# Altium schematic sheet USBC_B.SchDoc
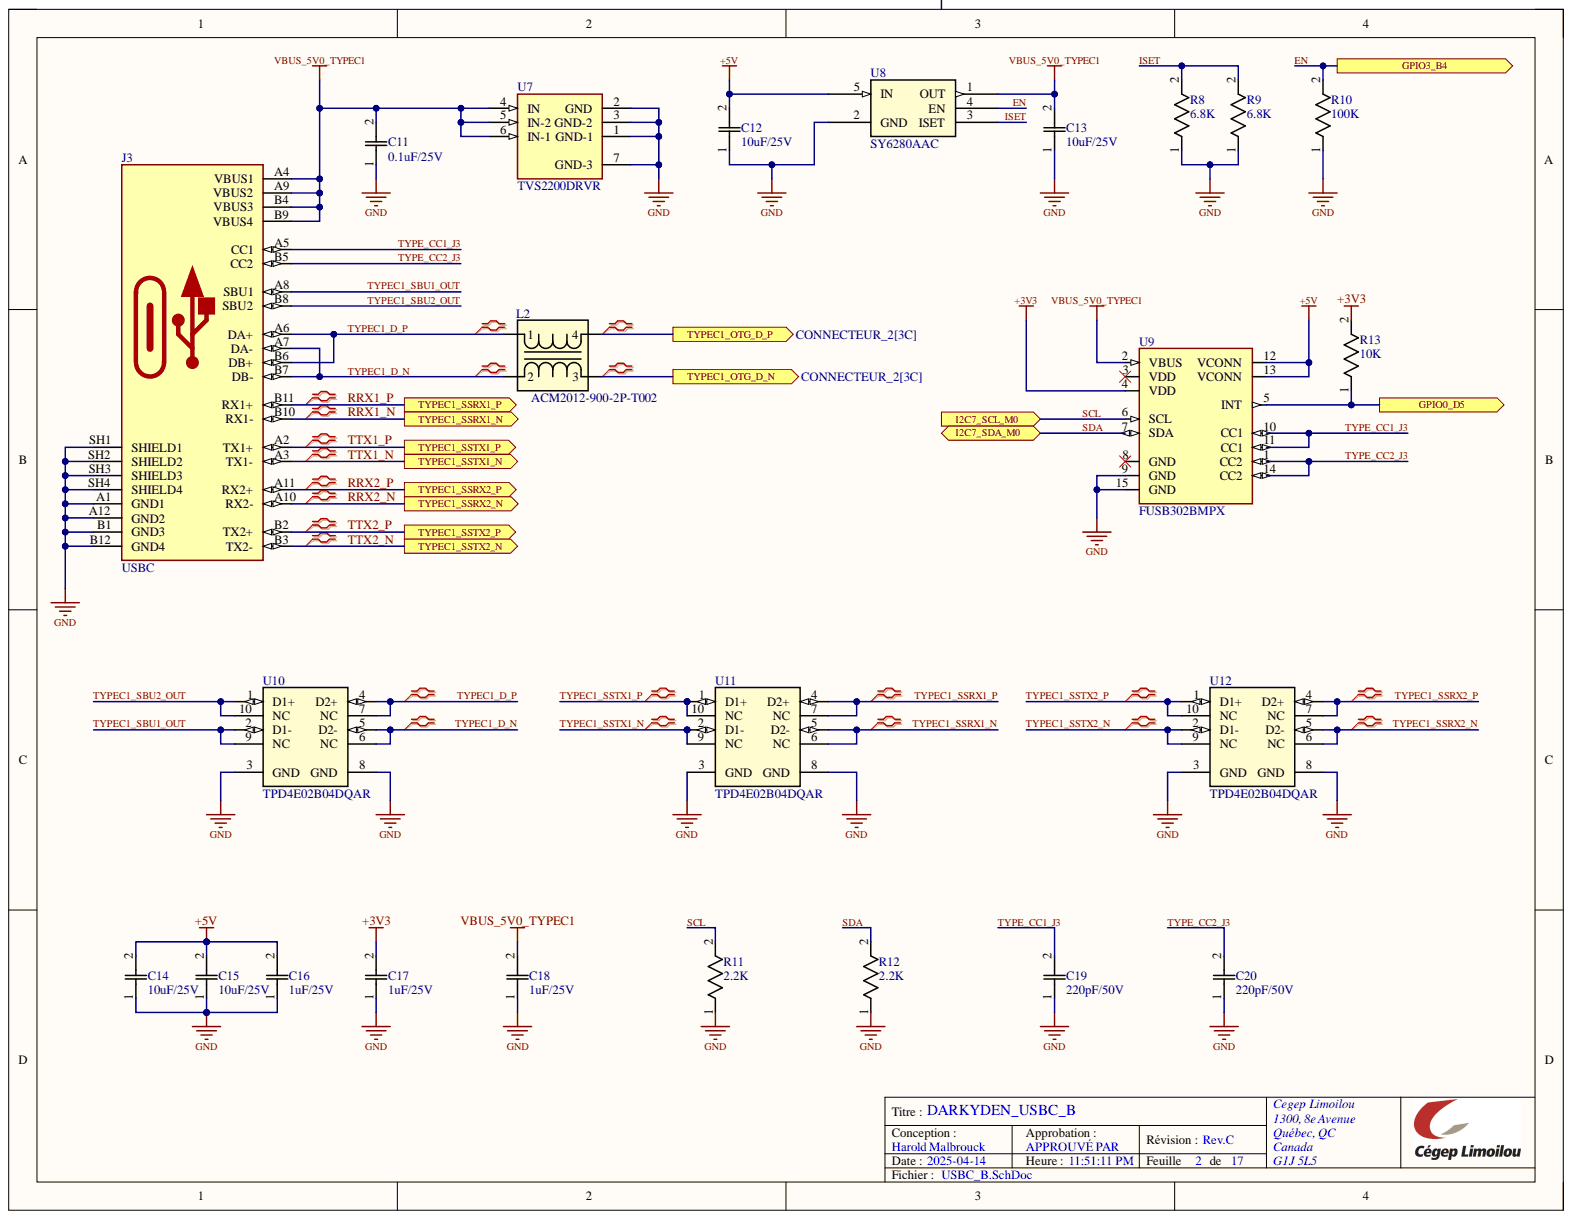
[diagram p0: USBC_B.SchDoc]

## Parts
C11  0.1uF/25V  [CAP_CC0805_YAG]
C12  10uF/25V  [CAP_CC0805_YAG]
C13  10uF/25V  [CAP_CC0805_YAG]
C14  10uF/25V  [CAP_CC0805_YAG]
C15  10uF/25V  [CAP_CC0805_YAG]
C16  1uF/25V  [CAP_CC0805_YAG]
C17  1uF/25V  [CAP_CC0805_YAG]
C18  1uF/25V  [CAP_CC0805_YAG]
C19  220pF/50V  [CAP_CC0805_YAG]
C20  220pF/50V  [CAP_CC0805_YAG]
J3  USBC  [MOLEX_2012670005]
L2  ACM2012-900-2P-T002  [ACM2012_TDK]
R8  6.8K  [RES_0816_SUS]
R9  6.8K  [RES_0816_SUS]
R10  100K  [RES_0816_SUS]
R11  2.2K  [RES_0816_SUS]
R12  2.2K  [RES_0816_SUS]
R13  10K  [RES_0816_SUS]
U7  TVS2200DRVR  [SON65P200X200X80-7N]
U8  SY6280AAC  [SOT23-5_SGY-M]
U9  FUSB302BMPX  [MLP-14]
U10  TPD4E02B04DQAR  [DQA0010A]
U11  TPD4E02B04DQAR  [DQA0010A]
U12  TPD4E02B04DQAR  [DQA0010A]

## Ports
GPIO0_D5, GPIO3_B4, I2C7_SCL_M0, I2C7_SDA_M0, TYPEC1_OTG_D_N, TYPEC1_OTG_D_P, TYPEC1_SSRX1_N, TYPEC1_SSRX1_P, TYPEC1_SSRX2_N, TYPEC1_SSRX2_P, TYPEC1_SSTX1_N, TYPEC1_SSTX1_P, TYPEC1_SSTX2_N, TYPEC1_SSTX2_P

## Nets
+3V3: C17.2 R13.2 U9.4(VDD)
+5V: C12.2 C14.2 C15.2 C16.2 U8.5(IN) U9.12(VCONN) U9.13(VCONN)
GND: C11.1 C12.1 C13.1 C14.1 C15.1 C16.1 C17.1 C18.1 C19.1 C20.1 J3.A1(GND1) J3.A12(GND2) J3.B1(GND3) J3.B12(GND4) J3.SH1(SHIELD1) J3.SH2(SHIELD2) J3.SH3(SHIELD3) J3.SH4(SHIELD4) R8.1 R9.1 R10.1 R11.1 R12.1 U7.1(GND-1) U7.2(GND) U7.3(GND-2) U7.7(GND-3) U8.2(GND) U9.9(GND) U9.15(GND) U10.3(GND) U10.8(GND) U11.3(GND) U11.8(GND) U12.3(GND) U12.8(GND)
VBUS_5V0_TYPEC1: C11.2 C13.2 C18.2 J3.A4(VBUS1) J3.A9(VBUS2) J3.B4(VBUS3) J3.B9(VBUS4) U7.4(IN) U7.5(IN-2) U7.6(IN-1) U8.1(OUT) U9.2(VBUS)
EN: R10.2 U8.4(EN) ; port GPIO3_B4
GPIO0_D5: R13.1 U9.5(INT) ; port GPIO0_D5
ISET: R8.2 R9.2 U8.3(ISET)
RRX1_N: J3.B10(RX1-) ; port TYPEC1_SSRX1_N
RRX1_P: J3.B11(RX1+) ; port TYPEC1_SSRX1_P
RRX2_N: J3.A10(RX2-) ; port TYPEC1_SSRX2_N
RRX2_P: J3.A11(RX2+) ; port TYPEC1_SSRX2_P
SCL: R11.2 U9.6(SCL) ; port I2C7_SCL_M0
SDA: R12.2 U9.7(SDA) ; port I2C7_SDA_M0
TTX1_N: J3.A3(TX1-) ; port TYPEC1_SSTX1_N
TTX1_P: J3.A2(TX1+) ; port TYPEC1_SSTX1_P
TTX2_N: J3.B3(TX2-) ; port TYPEC1_SSTX2_N
TTX2_P: J3.B2(TX2+) ; port TYPEC1_SSTX2_P
TYPE_CC1_J3: C19.2 J3.A5(CC1) U9.10(CC1) U9.11(CC1)
TYPE_CC2_J3: C20.2 J3.B5(CC2) U9.1(CC2) U9.14(CC2)
TYPEC1_D_N: J3.A7(DA-) J3.B7(DB-) L2.2 U10.5(D2-) U10.6(NC)
TYPEC1_D_P: J3.A6(DA+) J3.B6(DB+) L2.1 U10.4(D2+) U10.7(NC)
TYPEC1_OTG_D_N: L2.3 ; port TYPEC1_OTG_D_N
TYPEC1_OTG_D_P: L2.4 ; port TYPEC1_OTG_D_P
TYPEC1_SBU1_OUT: J3.A8(SBU1) U10.2(D1-) U10.9(NC)
TYPEC1_SBU2_OUT: J3.B8(SBU2) U10.1(D1+) U10.10(NC)
TYPEC1_SSRX1_N: U11.5(D2-) U11.6(NC)
TYPEC1_SSRX1_P: U11.4(D2+) U11.7(NC)
TYPEC1_SSRX2_N: U12.5(D2-) U12.6(NC)
TYPEC1_SSRX2_P: U12.4(D2+) U12.7(NC)
TYPEC1_SSTX1_N: U11.2(D1-) U11.9(NC)
TYPEC1_SSTX1_P: U11.1(D1+) U11.10(NC)
TYPEC1_SSTX2_N: U12.2(D1-) U12.9(NC)
TYPEC1_SSTX2_P: U12.1(D1+) U12.10(NC)

Not connected: U9 3(VDD) 8(GND)

## Library data of the parts
C11-C20 = Capacitor_0805: No Description Available; Mfr_Name: Yageo; Component Kind: Standard; Library Name: Capacitor_0805.SchLib
J3 = USBC: USB-C (USB TYPE-C) USB 3.2 Gen 2 (USB 3.1 Gen 2, Superspeed + (USB 3.1)) Receptacle Connector 24 Position Surface Mount, Right Angle; Through Hole; MF: Molex; MP: 2012670005; Check_prices: https://www.snapeda.com/parts/2012670005/Molex/view-part/?ref=eda; Component Kind: Standard; Library Name: USBC.SchLib; Package: None; SnapEDA_Link: https://www.snapeda.com/parts/2012670005/Molex/view-part/?ref=snap
L2 = ACM2012-900-2P-T002: No Description Available; Mfr_Name: TDK; Component Kind: Standard; Library Name: ACM2012.SchLib
R8-R13 = RG1608P-104-B-T5: No Description Available; Mfr_Name: Susumu; Component Kind: Standard; Library Name: RES_SMD_0603.SchLib
U7 = TVS2200DRVR: 22V flat-clamp surge protection device; MF: Texas Instruments; Check_prices: https://www.snapeda.com/parts/TVS2200DRVR/Texas+Instruments/view-part/?ref=eda; Component Kind: Standard; Library Name: TVS2200.SchLib; MP: TVS2200DRVR; Package: WSON-6 Texas Instruments; SnapEDA_Link: https://www.snapeda.com/parts/TVS2200DRVR/Texas+Instruments/view-part/?ref=snap
U8 = SY6280AAC: No Description Available; Mfr_Name: Silergy; Component Kind: Standard; Library Name: SY6280AAC.SchLib; RefDes: RefDes; Type: Type
U9 = FUSB302BMPX: USB Controller USB 3.1 USB Interface 14-MLP (2.5x2.5); MF: ON Semiconductor; Check_prices: https://www.snapeda.com/parts/FUSB302BMPX/Onsemi/view-part/?ref=eda; Component Kind: Standard; Library Name: FUSB302BMPX.SchLib; MP: FUSB302BMPX; Package: WQFN-14 ON Semiconductor; SnapEDA_Link: https://www.snapeda.com/parts/FUSB302BMPX/Onsemi/view-part/?ref=snap
U10-U12 = TPD4E02B04DQAR: No Description Available; Manufacturer: Texas Instruments; CompType: IC; Component Kind: Standard; Library Name: TPD4E02B04DQAR.SchLib; Mfr_Name: Texas Instruments; MountType: SMT; PackageReference: DQA0010A; PartNumber: TPD4E02B04DQAR; RefDes: RefDes; RoHS: Y; TYPE: TYPE
